# Altium schematic sheet TOF-LG-1A.SchDoc
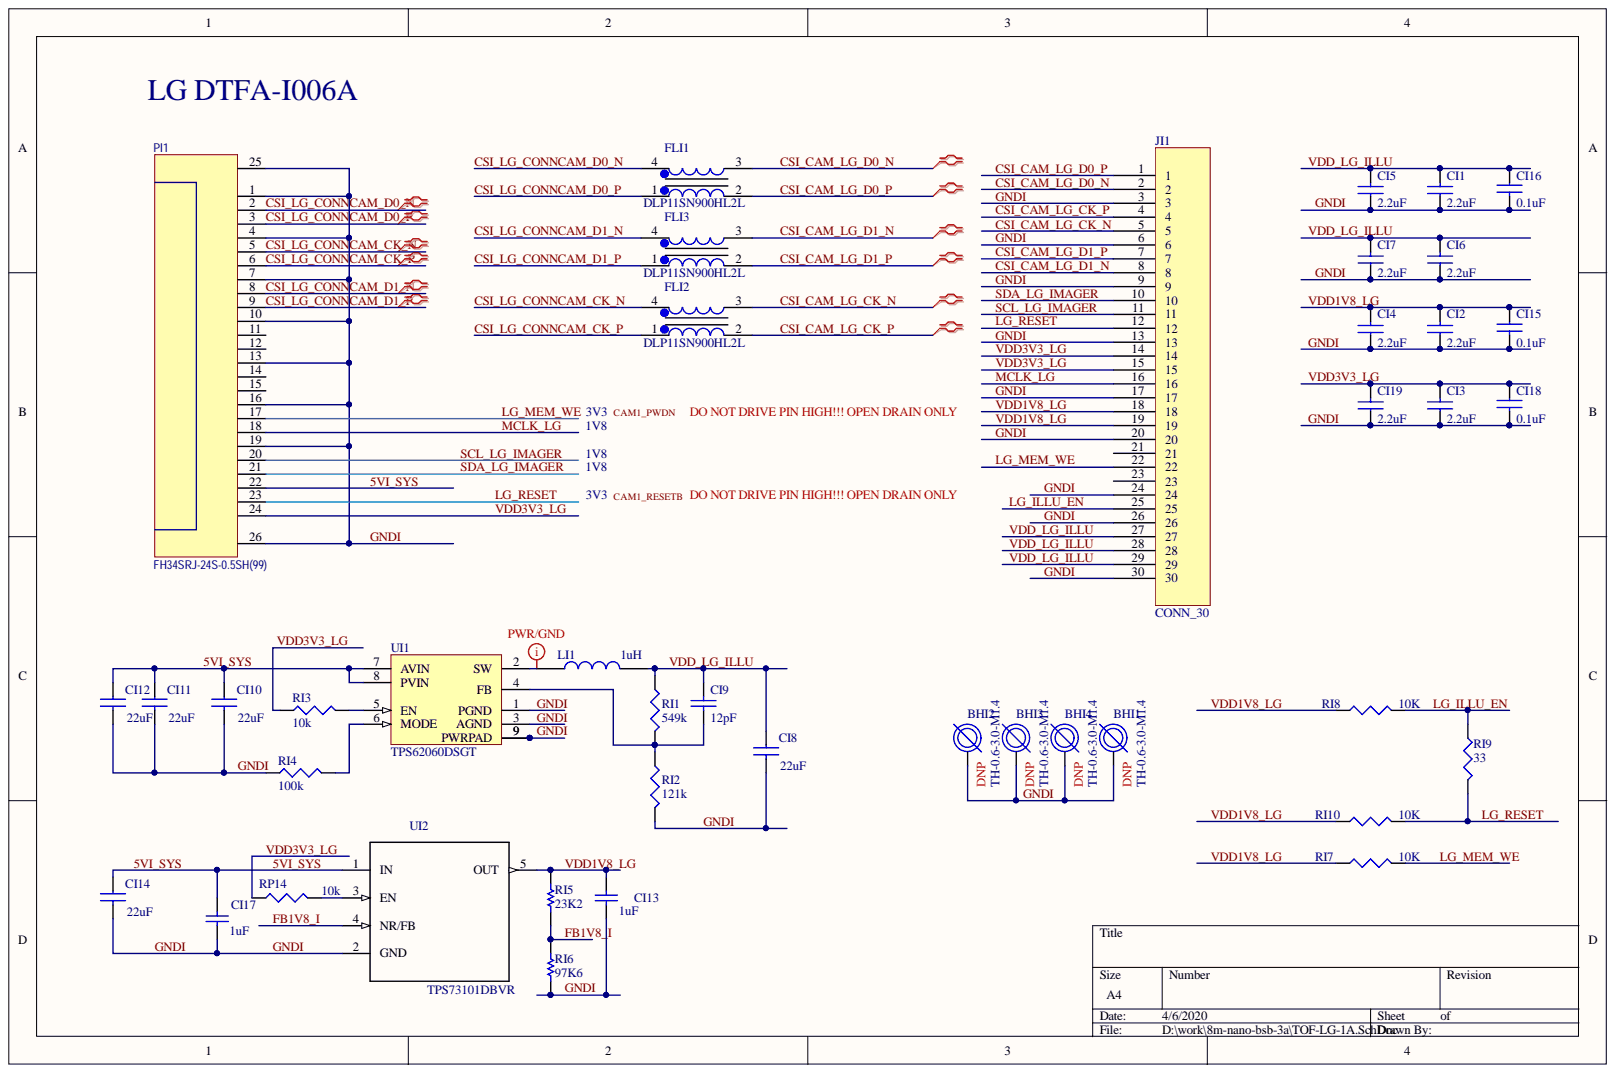
[diagram p0: TOF-LG-1A.SchDoc]

## Parts
BHI1  TH-0.6-3.0-M1.4  Notes: DNP  [HOLE120-80]
BHI2  TH-0.6-3.0-M1.4  Notes: DNP  [HOLE120-80]
BHI3  TH-0.6-3.0-M1.4  Notes: DNP  [HOLE120-80]
BHI4  TH-0.6-3.0-M1.4  Notes: DNP  [HOLE120-80]
CI1  2.2uF  [CAPC1608X95N]
CI2  2.2uF  [CAPC1608X95N]
CI3  2.2uF  [CAPC1608X95N]
CI4  2.2uF  [CAPC1608X95N]
CI5  2.2uF  [CAPC1608X95N]
CI6  2.2uF  [CAPC1608X95N]
CI7  2.2uF  [CAPC1608X95N]
CI8  22uF  [CAPC1608X95N]
CI9  12pF  [CAPC1005X60N]
CI10  22uF  [CAPC1608X95N]
CI11  22uF  [CAPC1608X95N]
CI12  22uF  [CAPC1608X95N]
CI13  1uF  [C0402]
CI14  22uF  [CAPC1608X95N]
CI15  0.1uF  [CAPC1005X60N]
CI16  0.1uF  [CAPC1005X60N]
CI17  1uF  [C0402]
CI18  0.1uF  [CAPC1005X60N]
CI19  2.2uF  [CAPC1608X95N]
FLI1  DLP11SN900HL2L  [FIL_DLP11SN900HL2L]
FLI2  DLP11SN900HL2L  [FIL_DLP11SN900HL2L]
FLI3  DLP11SN900HL2L  [FIL_DLP11SN900HL2L]
JI1  CONN_30  [BM23FR0.6-30DS-0.35V(895)]
LI1  1uH  [INDM4040X180N]
PI1  FH34SRJ-24S-0.5SH(99)  [FPC24-0_5-14_18x4_71MM]
RI1  549k  [RESC1005N]
RI2  121k  [RESC1005N]
RI3  10k  [RESC1005N]
RI4  100k  [RESC1005N]
RI5  23K2  [R0402]
RI6  97K6  [R0402]
RI7  10K  [R0402]
RI8  10K  [R0402]
RI9  33  [R0402]
RI10  10K  [R0402]
RP14  10k  [RESC1005N]
UI1  TPS62060DSGT  [SON50P200X200X80-9N]
UI2  TPS73101DBVR  [*SOT95P280X145-5N]

## Nets
5VI_SYS: CI10.1 CI11.1 CI12.1 CI14.1 CI17.2 PI1.22 UI1.7(AVIN) UI1.8(PVIN) UI2.1(IN)
CSI_CAM_LG_CK_N: FLI2.3 JI1.5
CSI_CAM_LG_CK_P: FLI2.2 JI1.4
CSI_CAM_LG_D0_N: FLI1.3 JI1.2
CSI_CAM_LG_D0_P: FLI1.2 JI1.1
CSI_CAM_LG_D1_N: FLI3.3 JI1.8
CSI_CAM_LG_D1_P: FLI3.2 JI1.7
CSI_LG_CONNCAM_CK_N: FLI2.4 PI1.5
CSI_LG_CONNCAM_CK_P: FLI2.1 PI1.6
CSI_LG_CONNCAM_D0_N: FLI1.4 PI1.2
CSI_LG_CONNCAM_D0_P: FLI1.1 PI1.3
CSI_LG_CONNCAM_D1_N: FLI3.4 PI1.8
CSI_LG_CONNCAM_D1_P: FLI3.1 PI1.9
FB1V8_I: RI5.1 RI6.2 UI2.4(NR/FB)
GNDI: BHI1.1 BHI2.1 BHI3.1 BHI4.1 CI1.1 CI2.1 CI3.1 CI4.1 CI5.1 CI6.1 CI7.1 CI8.2 CI10.2 CI11.2 CI12.2 CI13.1 CI14.2 CI15.2 CI16.2 CI17.1 CI18.2 CI19.1 JI1.3 JI1.6 JI1.9 JI1.13 JI1.17 JI1.20 JI1.24 JI1.26 JI1.30 PI1.1 PI1.4 PI1.7 PI1.10 PI1.13 PI1.16 PI1.19 PI1.25 PI1.26 RI2.2 RI4.1 RI6.1 UI1.1(PGND) UI1.3(AGND) UI1.9(PWRPAD) UI2.2(GND)
LG_ILLU_EN: JI1.25 RI8.2 RI9.2
LG_MEM_WE: JI1.22 PI1.17 RI7.2
LG_RESET: JI1.12 PI1.23 RI9.1 RI10.2
MCLK_LG: JI1.16 PI1.18
SCL_LG_IMAGER: JI1.11 PI1.20
SDA_LG_IMAGER: JI1.10 PI1.21
VDD1V8_LG: CI2.2 CI4.2 CI13.2 CI15.1 JI1.18 JI1.19 RI5.2 RI7.1 RI8.1 RI10.1 UI2.5(OUT)
VDD3V3_LG: CI3.2 CI18.1 CI19.2 JI1.14 JI1.15 PI1.24 RI3.2 RP14.2
VDD_LG_ILLU: CI1.2 CI5.2 CI6.2 CI7.2 CI8.1 CI9.1 CI16.1 JI1.27 JI1.28 JI1.29 LI1.2 RI1.1
NetCI9_2: CI9.2 RI1.2 RI2.1 UI1.4(FB)
NetLI1_1: LI1.1 UI1.2(SW)
NetRI3_1: RI3.1 UI1.5(EN)
NetRI4_2: RI4.2 UI1.6(MODE)
NetRP14_1: RP14.1 UI2.3(EN)
CAM1_PWDN:
CAM1_RESETB:

Not connected: JI1 21 23; PI1 11 12 14 15

## Library data of the parts
BHI1-BHI4 = MOUNT_HOLE: TH-0.6-3.0-M1.4 / https://www.mac8japan.com/products/458; Manufacturer: Mac8; Component Kind: Standard; ManType: TH-0.6-3.0-M1.4
CI1-CI7 CI19 = CAP NP: CAP CER 2.2uF 6.3V 10% X5R 0603; Manufacturer: Würth Elektronik; ManType: 885012105013; Component Kind: Standard; Digikey: 732-7490-6-ND
CI8 CI10-CI12 CI14 = CAP NP: 22uF +-20% 6.3V Ceramic Capacitor X5R 0603; Manufacturer: Samsung; Emcraft #: E-CAP-049; ManType: CL10A226MQ8NRNC; Component Kind: Standard; Digikey: 1276-1193-1-ND; Package: 0603; Part #: E-CAP-049; Price 100: 0.0850; Price 1000: 0.05250
CI9 = CAP: CAP CER 12PF 50V 5% NPO 0402; Manufacturer: Yageo; Emcraft #: E-CAP-024; ManType: CC0402JRNPO9BN120; Component Kind: Standard; Digikey: 311-1016-1-ND; Library Name: Main.SCHLIB; Package: 0402; Part #: E-CAP-024; Price 100: 0.0023
CI13 CI17 = Cap_1uF_X5R_10%_6V3_0402: CAP CER 1UF 10V X5R 0402; Manufacturer: Würth Elektronik; ManType: 885012105012; Component Kind: Standard; Digikey: 732-7489-6-ND; Library Name: MCD_Temp_LBE.SchLib
CI15 CI16 CI18 = CAP NP: CAP CER 0.1UF 25V X5R 0402; Manufacturer: Würth Elektronik; ManType: 885012105018; Component Kind: Standard; Digikey: 732-7495-1-ND
FLI1-FLI3 = DLP11SN900HL2L: MURATA# DLP11SN900HL2L, D LP Series 90 Ohm Impedanc e 20 % 150 mA DCR 1.5 Ohm SMT Common Mode Choke; Manufacturer: Murata; Part #: LF-IDT-001; Component Kind: Standard; DigiKey: 490-5143-1-ND; Library Name: DLP11SN900HL2L.SchLib; ManType: DLP11SN900HL2L
JI1 = CONN_30: CONN SOCKET .4MM 30 POS SMD; Manufacturer: Hirose Electric Co Ltd; Part #: E-CONN-060; Component Kind: Standard; DigiKey: H125632CT-ND; ManType: BM23FR0.6-30DS-0.35V(895); Library Name: IMX8M-TOF.SchLib
LI1 = INDUCTOR: FIXED IND 1UH 2A 25 MOHM SMD; Manufacturer: Laird-Signal Integrity Products; Emcraft #: E-IDT-025; ManType: TYS40181R0N-10; Component Kind: Standard; Digikey: 240-2671-2-ND; Library Name: Main.SCHLIB; Package: Non standard; Part #: E-IDT-025
PI1 = CONN_ACES_50696-0240MV02: CONN FPC/FFC 24POS .5MM; Manufacturer: Hirose Electric Co Ltd; Component Kind: Standard; DigiKey: H124725CT-ND; ManType: FH34SRJ-24S-0.5SH(99); Library Name: SpacePark.SchLib
RI1 = R_SH: RES 549K OHM 1/16W 1% 0402; Manufacturer: Samsung; Part #: E-RES-035; ManType: RC1005F5493CS; Component Kind: Standard; Digikey: 1276-3472-6-ND; Package: 0402; Price: 0.002
RI2 = R_SH: RES 121K OHM 1/16W 1% 0402; Manufacturer: Samsung; Part #: E-RES-036; ManType: RC1005F1213CS; Component Kind: Standard; Digikey: 1276-4209-6-ND; Package: 0402; Price: 0.0015
RI3 RP14 = R_SM: RES 10K OHM 1/16W 5% 0402; Manufacturer: Stackpole Electronics Inc; Part #: E-RES-003; ManType: RMCF0402JT10K0; Component Kind: Standard; Digikey: RMCF0402JT10K0CT-ND; Package: 0402; Price 100: 0.00700
RI4 = R_SH: RES SMD 100K OHM 5% 1/16W 0402; Manufacturer: Vishay Dale; Emcraft #: E-RES-031; ManType: RMCF0402JT100K; Component Kind: Standard; Digikey: RMCF0402JT100KCT-ND; Package: 0402; Part #: E-RES-031; Price: 0.00378
RI5 = Res_10K_1%_0402: RES SMD 23.2K OHM 1% 1/16W 0402; Manufacturer: YAGEO; Part #: E-RES-075; ManType: RC0402FR-0723K2L; Component Kind: Standard; Package: 0402; Library Name: MCD_Temp_LBE.SchLib
RI6 = Res_10K_1%_0402: RES SMD 97.6K OHM 1% 1/16W 0402; Manufacturer: STACKPOLE; Part #: E-RES-061; ManType: RMCF0402FT97K6; Component Kind: Standard; Package: 0402; Library Name: MCD_Temp_LBE.SchLib
RI7 RI8 RI10 = R_SM: RES 10K OHM 1/16W 5% 0402; Manufacturer: Stackpole Electronics Inc; ManType: RMCF0402JT10K0; Component Kind: Standard; DigiKey: RMCF0402JT10K0CT-ND
RI9 = R_SH: RES 33 OHM 1/16W 5% 0402; Manufacturer: Stackpole Electronics Inc; Part #: E-RES-004; ManType: RMCF0402JT33R0; Component Kind: Standard; Digikey: RMCF0402JT33R0CT-ND; Library Name: main.SchLib; Package: 0402; Price 100: 0.00700
UI1 = TPS62060_1: IC REG BUCK ADJ 1.6A 8WSON; Manufacturer: Texas Instruments; Component Kind: Standard; DigiKey: 296-27229-6-ND; Library Name: main.SchLib; ManType: TPS62060DSG
UI2 = TPS73101DBVR: IC REG LIN POS ADJ 150MA SOT23-5; Manufacturer: Texas Instruments; Component Kind: Standard; DigiKey: 296-27058-1-ND; Library Name: TPS73101DBVR.SchLib; ManType: TPS73101DBVR
